# Altium schematic sheet Sheet1.SchDoc(Sheet1)
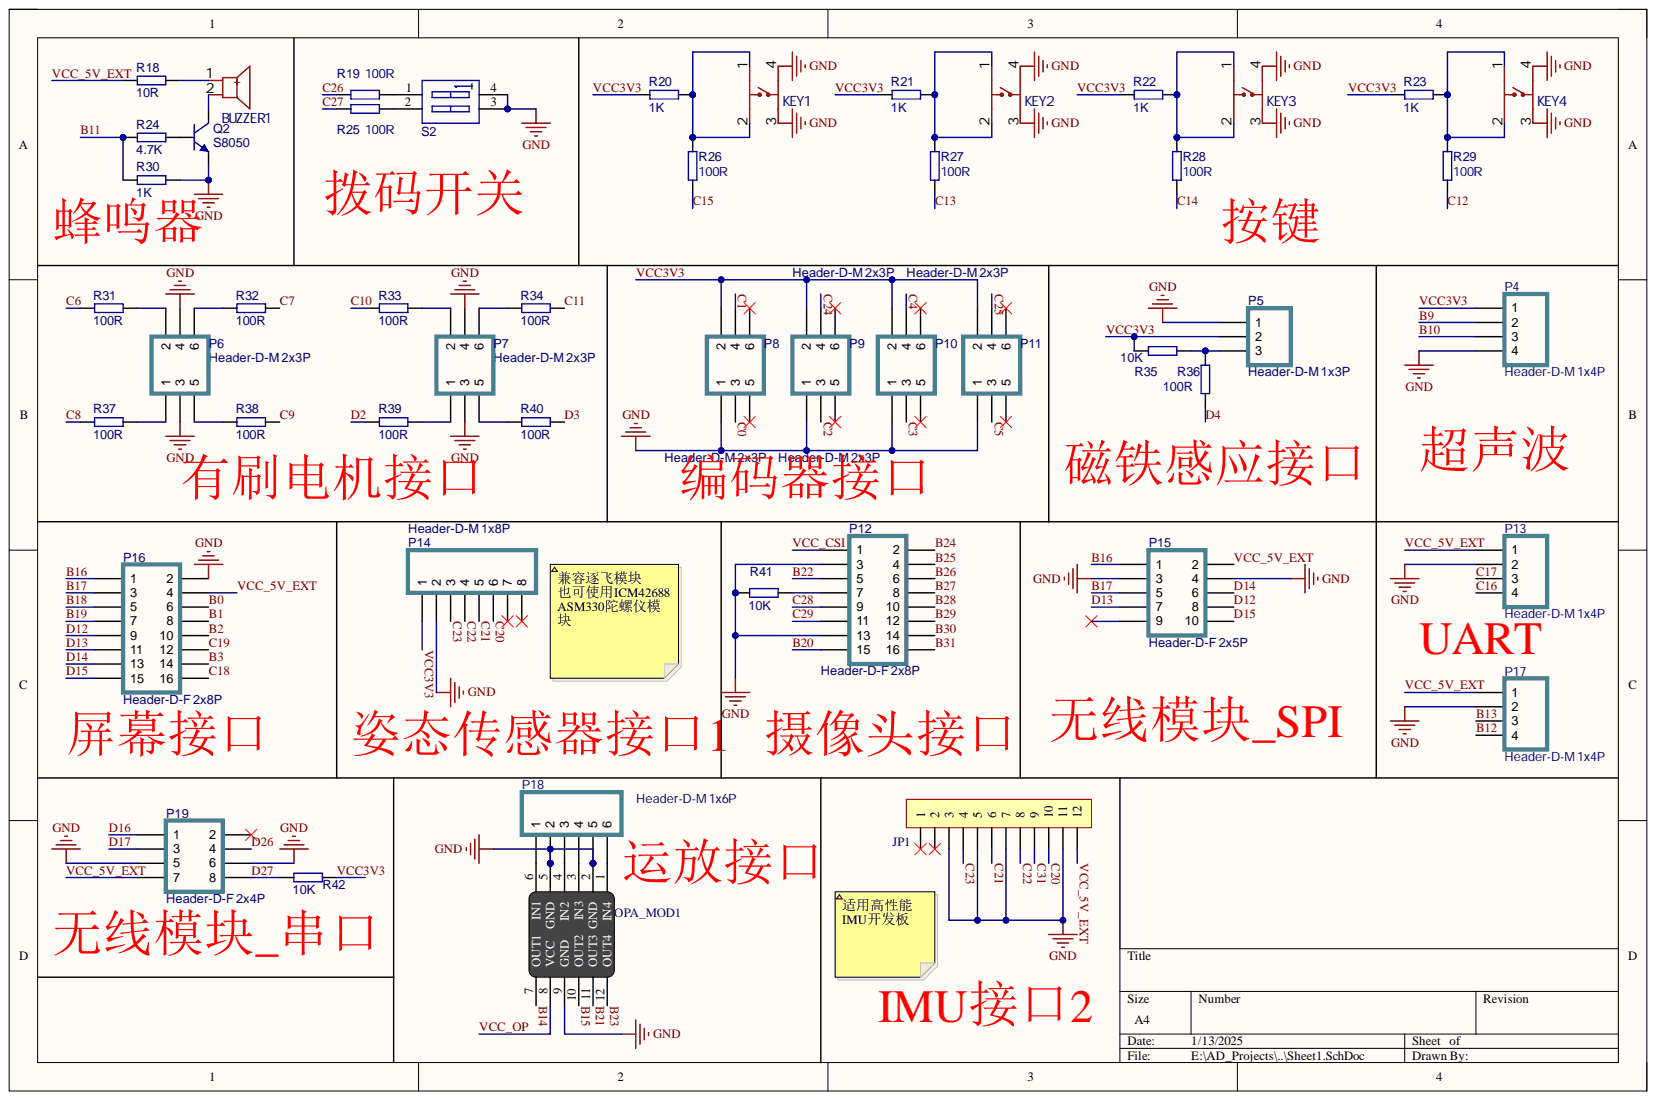
[diagram p0: Sheet1.SchDoc]

## Parts
BUZZER1  3kHz  [BUZ-TH_BD9.6-P5.00-D0.7-FD]  (value not drawn)
JP1  CON-12P  [FPC1.0-WT-12P]  (value not drawn)
KEY1  B3F-4055  [B3F-4055]  (value not drawn)
KEY2  B3F-4055  [B3F-4055]  (value not drawn)
KEY3  B3F-4055  [B3F-4055]  (value not drawn)
KEY4  B3F-4055  [B3F-4055]  (value not drawn)
OPA_MOD1  OPA_MOD  [SEEKFREE_4CHANEL_OPAMOD]
P4  Header-D-M 1x4P  [HDR1X4-M]
P5  Header-D-M 1x3P  [HDR1X3-M]
P6  Header-D-M 2x3P  [HDR2X3-M]
P7  Header-D-M 2x3P  [HDR2X3-M]
P8  Header-D-M 2x3P  [HDR2X3-M]
P9  Header-D-M 2x3P  [HDR2X3-M]
P10  Header-D-M 2x3P  [HDR2X3-M]
P11  Header-D-M 2x3P  [HDR2X3-M]
P12  Header-D-F 2x8P  [HDR2X8-F]
P13  Header-D-M 1x4P  [HDR1X4-M]
P14  Header-D-M 1x8P  [HDR1X8-M]
P15  Header-D-F 2x5P  [HDR2X5-F]
P16  Header-D-F 2x8P  [HDR2X8-F]
P17  Header-D-M 1x4P  [HDR1X4-M]
P18  Header-D-M 1x6P  [HDR1X6-M]
P19  Header-D-F 2x4P  [HDR2X4-F]
Q2  S8050  [SOT-23]
R18  value 10R  [RESC1608[0603]S-N]  (hidden comment: 10R ±1%)
R19  100R ±1%  value 100R  [RESC1608[0603]S-L]
R20  value 1K  [RESC1608[0603]S-L]  (hidden comment: 1K ±1%)
R21  value 1K  [RESC1608[0603]S-L]  (hidden comment: 1K ±1%)
R22  value 1K  [RESC1608[0603]S-L]  (hidden comment: 1K ±1%)
R23  value 1K  [RESC1608[0603]S-L]  (hidden comment: 1K ±1%)
R24  value 4.7K  [RESC1608[0603]S-N]  (hidden comment: 4.7K ±1%)
R25  100R ±1%  value 100R  [RESC1608[0603]S-L]
R26  value 100R  [RESC1608[0603]S-L]  (hidden comment: 100R ±1%)
R27  value 100R  [RESC1608[0603]S-L]  (hidden comment: 100R ±1%)
R28  value 100R  [RESC1608[0603]S-L]  (hidden comment: 100R ±1%)
R29  value 100R  [RESC1608[0603]S-L]  (hidden comment: 100R ±1%)
R30  value 1K  [RESC1608[0603]S-N]  (hidden comment: 1K ±1%)
R31  value 100R  [RESC1608[0603]S-N]  (hidden comment: 100R ±1%)
R32  value 100R  [RESC1608[0603]S-N]  (hidden comment: 100R ±1%)
R33  value 100R  [RESC1608[0603]S-N]  (hidden comment: 100R ±1%)
R34  value 100R  [RESC1608[0603]S-N]  (hidden comment: 100R ±1%)
R35  value 10K  [RESC1608[0603]S-N]  (hidden comment: 10K ±1%)
R36  value 100R  [RESC1608[0603]S-N]  (hidden comment: 100R ±1%)
R37  value 100R  [RESC1608[0603]S-N]  (hidden comment: 100R ±1%)
R38  value 100R  [RESC1608[0603]S-N]  (hidden comment: 100R ±1%)
R39  value 100R  [RESC1608[0603]S-N]  (hidden comment: 100R ±1%)
R40  value 100R  [RESC1608[0603]S-N]  (hidden comment: 100R ±1%)
R41  value 10K  [RESC1608[0603]S-L]  (hidden comment: 10K ±1%)
R42  value 10K  [RESC1608[0603]S-L]  (hidden comment: 10K ±1%)
S2  SW DIP-2  [Code SW-2-DIP-2.54]  (value not drawn)

## Nets
GND: JP1.3 JP1.5 JP1.7 JP1.11 KEY1.3 KEY1.4 KEY2.3 KEY2.4 KEY3.3 KEY3.4 KEY4.3 KEY4.4 OPA_MOD1.2(GND) OPA_MOD1.5(GND) OPA_MOD1.9(GND) P4.4 P5.1 P6.3 P6.4 P7.3 P7.4 P8.1 P9.1 P10.1 P11.1 P12.3 P12.13 P13.2 P14.2 P15.3 P15.4 P16.2 P17.2 P18.2 P18.5 P19.5 P19.6 Q2.2 R30.2 R41.1 S2.3 S2.4
B0: P16.6
B1: P16.8
B2: P16.10
B3: P16.14
B9: P4.2
B10: P4.3
B11: R24.1 R30.1
B12: P17.4
B13: P17.3
B14: OPA_MOD1.7(OUT1)
B15: OPA_MOD1.10(OUT2)
B16: P15.1 P16.1
B17: P15.5 P16.3
B18: P16.5
B19: P16.7
B20: P12.15
B21: OPA_MOD1.11(OUT3)
B22: P12.5
B23: OPA_MOD1.12(OUT4)
B24: P12.2
B25: P12.4
B26: P12.6
B27: P12.8
B28: P12.10
B29: P12.12
B30: P12.14
B31: P12.16
C0: P8.3
C1: P8.4
C2: P9.3
C3: P10.3
C4: P10.4
C5: P11.3
C6: R31.1
C7: R32.2
C8: R37.1
C9: R38.2
C10: R33.1
C11: R34.2
C12: R29.1
C13: R27.1
C14: R28.1
C15: R26.1
C16: P13.4
C17: P13.3
C18: P16.16
C19: P16.12
C20: JP1.10 P14.6
C21: JP1.6 P14.5
C22: JP1.8 P14.4
C23: JP1.4 P14.3
C24: P9.4
C25: P11.4
C26: R19.1
C27: R25.1
C28: P12.9
C29: P12.11
C31: JP1.9
D2: R39.1
D3: R40.2
D4: R36.1
D12: P15.8 P16.9
D13: P15.7 P16.11
D14: P15.6 P16.13
D15: P15.10 P16.15
D16: P19.1
D17: P19.3
D26: P19.4
D27: P19.8 R42.1
VCC3V3: P4.1 P5.2 P8.2 P9.2 P10.2 P11.2 P14.1 R20.1 R21.1 R22.1 R23.1 R35.1 R42.2
VCC_5V_EXT: JP1.12 P13.1 P15.2 P16.4 P17.1 P19.7 R18.1
VCC_CSI: P12.1
VCC_OP: OPA_MOD1.8(VCC)
NetBUZZER1_1: BUZZER1.1 R18.2
NetBUZZER1_2: BUZZER1.2 Q2.3
NetKEY1_1: KEY1.1 KEY1.2 R20.2 R26.2
NetKEY2_1: KEY2.1 KEY2.2 R21.2 R27.2
NetKEY3_1: KEY3.1 KEY3.2 R22.2 R28.2
NetKEY4_1: KEY4.1 KEY4.2 R23.2 R29.2
NetOPA_MOD1_1: OPA_MOD1.1(IN4) P18.6
NetOPA_MOD1_3: OPA_MOD1.3(IN3) P18.4
NetOPA_MOD1_4: OPA_MOD1.4(IN2) P18.3
NetOPA_MOD1_6: OPA_MOD1.6(IN1) P18.1
NetP5_3: P5.3 R35.2 R36.2
NetP6_1: P6.1 R37.2
NetP6_2: P6.2 R31.2
NetP6_5: P6.5 R38.1
NetP6_6: P6.6 R32.1
NetP7_1: P7.1 R39.2
NetP7_2: P7.2 R33.2
NetP7_5: P7.5 R40.1
NetP7_6: P7.6 R34.1
NetP12_7: P12.7 R41.2
NetQ2_1: Q2.1 R24.2
NetR19_2: R19.2 S2.1
NetR25_2: R25.2 S2.2

Not connected: JP1 1 2; P8 5 6; P9 5 6; P10 5 6; P11 5 6; P14 7 8; P15 9; P19 2

## Library data of the parts
BUZZER1 = HNB09A05: 驱动方式:有源(内含驱动线路);构造类型:电磁式;频率:3kHz;声压(SPL):85dB;; Manufacturer: 华能; Add into BOM: yes; Operating Voltage: 4V~8V; Sound Pressure Level (SPL): 85dB; Technology Type: 电磁式; Component Kind: Standard; Convert to PCB: yes; Datasheet: https://atta.szlcsc.com/upload/public/pdf/source/20170522/1495448327549.pdf; Device: HNB09A05; Diameter (φD): 9mm; Height: 5.7mm; JLCPCB Part Class: 扩展库; LCSC Part Name: HNB09A05; Library Name: Tc2XX_MotherBoard.SCHLIB; Manufacturer Part: HNB09A05; Oscillator Circuit: 有源(内含驱动线路); PCB3D: BUZ-TH_D9.0-H5.5-P5.0; Supplier: LCSC; Supplier Footprint: 插件,D=9mm; Supplier Part: C96493; Symbol: HNB09A05; Value: 3kHz
JP1 = Header 12: 12P连接器; 封装库来自淘宝卖家：黄晶宝石: 获取最新版本https://shop463601444.taobao.com; BOM名称: 12P连接器; Component Kind: Standard; Library Name: 5.0 - 1.00mm连接器(FPC1.0_SH1.0_1.0排针排母).SchLib
KEY1-KEY4 = B3F-4055_C84931: 电路结构:单刀单掷;按钮形状:矩形按钮;安装方式:直插;开关长度:12mm;开关宽度:12mm;开关高度:7.3mm;触点电流:50mA;额定电压(DC):24V;带灯:-;机械寿命:-;; Manufacturer: OMRON(欧姆龙); Actuator Style: 矩形按钮; Add into BOM: yes; Circuit: 单刀单掷; Contact Current: 50mA; Switch Height: 7.3mm; Switch Length: 12mm; Voltage Rating (DC): 24V; Component Kind: Standard; Convert to PCB: yes; Datasheet: https://atta.szlcsc.com/upload/public/pdf/source/20170810/C93157_1502335414592880008.pdf; Device: B3F-4055_C84931; LCSC Part Name: 12*12*7.3mm 直插 轻触开关; Library Name: Tc2XX_MotherBoard.SCHLIB; Manufacturer Part: B3F-4055; Mechanical Life: -; Mounting Style: 直插; PCB3D: KEY-TH_4P-L12.0-W12.0-H7.5-LS12.5-P5.0; Supplier: LCSC; Supplier Footprint: 插件; Supplier Part: C84931; Switch Width: 12mm; Symbol: B3F-4055; With Lamp: -
OPA_MOD1 = OPA_4CHANNEL_SEEKFREE: OPA module; Component Kind: Standard; Library Name: RT1064 主板 V3.0 器件封装集成库.IntLib
P4 P13 P17 = Header-D-M 1x4P: 1x4pin, 直插, 排针; Component Kind: Standard; Revision: V1.0; Value: Header-D-M 1x4P; Library Name: RT1064 主板 V3.0 器件封装集成库.IntLib
P5 = Header-D-M 1x3P: 1x3pin, 直插, 排针; Component Kind: Standard; Library Name: TC264_主板_V2.6.SCHLIB; Revision: V1.0; Value: Header-D-M 1x3P
P6-P11 = Header-D-M 2x3P: 2x3pin, 直插, 双排针; Component Kind: Standard; Library Name: TC264_主板_V2.6.SCHLIB; Revision: V1.0; Value: Header-D-M 2x3P
P12 P16 = Header-D-F 2x8P: 2x8pin, 直插, 双排座; Component Kind: Standard; Library Name: TC264_主板_V2.6.SCHLIB; Revision: V1.0; Value: Header-D-F 2x8P
P14 = Header-D-M 1x8P: 1x8pin, 直插, 排针; Component Kind: Standard; Library Name: TC264_主板_V2.6.SCHLIB; Revision: V1.0; Value: Header-D-M 1x8P
P15 = Header-D-F 2x5P: 2x5pin, 直插, 双排座; Component Kind: Standard; Library Name: TC264_主板_V2.6.SCHLIB; Revision: V1.0; Value: Header-D-F 2x5P
P18 = Header-D-M 1x6P: 1x6pin, 直插, 排针; Component Kind: Standard; Revision: V1.0; Value: Header-D-M 1x6P; Library Name: RT1064 主板 V3.0 器件封装集成库.IntLib
P19 = Header-D-F 2x4P: 2x4pin, 直插, 双排座; Component Kind: Standard; Library Name: TC264_主板_V2.6.SCHLIB; Revision: V1.0; Value: Header-D-F 2x4P
Q2 = NPN S8050: NPN 三极管; Component Kind: Standard; Library Name: TC264_主板_V2.6.SCHLIB; PartNumber: S8050; Price(RMB): 0.000; Published: 8-Jun-2000; Revision: July-2002: Re-released for DXP Platform.; Publisher: Altium Limited
R18 = Res-Chip_10: 贴片电阻; Power: 1/8W; Component Kind: Standard; Library Name: TC264_主板_V2.6.SCHLIB; Revision: V1.0; Tolerance: ±1%
R19 R25-R29 = Res-Chip_2: 贴片电阻; Component Kind: Standard; Published: 8-Jun-2000; Revision: July-2002: Re-released for DXP Platform.; Tolerance: ±1%; Library Name: RT1064 主板 V3.0 器件封装集成库.IntLib
  R19 R25: Value: 100R
R20-R23 = Res-Chip_11: 贴片电阻; Component Kind: Standard; Published: 8-Jun-2000; Revision: July-2002: Re-released for DXP Platform.; Tolerance: ±1%; Library Name: RT1064 主板 V3.0 器件封装集成库.IntLib
R24 = Res-Chip_3: 贴片电阻; Power: 1/8W; Component Kind: Standard; Library Name: TC264_主板_V2.6.SCHLIB; Revision: V1.0; Tolerance: ±1%
R30 = Res-Chip_11: 贴片电阻; Power: 1/8W; Component Kind: Standard; Library Name: TC264_主板_V2.6.SCHLIB; Revision: V1.0; Tolerance: ±1%
R31-R34 R36-R40 = Res-Chip_9: 贴片电阻; Power: 1/8W; Component Kind: Standard; Library Name: TC264_主板_V2.6.SCHLIB; Revision: V1.0; Tolerance: ±1%
R35 R41 R42 = Res-Chip: 贴片电阻; Power: 1/8W; Component Kind: Standard; Revision: V1.0; Tolerance: ±1%
  R35: Library Name: TC264_主板_V2.6.SCHLIB
  R41 R42: Library Name: RT1064 主板 V3.0 器件封装集成库.IntLib
S2 = Code SW-2: 2位拨码开关; Component Kind: Standard; Library Name: TC264_主板_V2.6.SCHLIB; Price(RMB): 0.000; Published: 24-Mar-1999; Revision: July-2002: Re-released for DXP Platform.; Publisher: Altium Limited
